# Altium schematic sheet fanled2014.SchDoc(fanled2014)
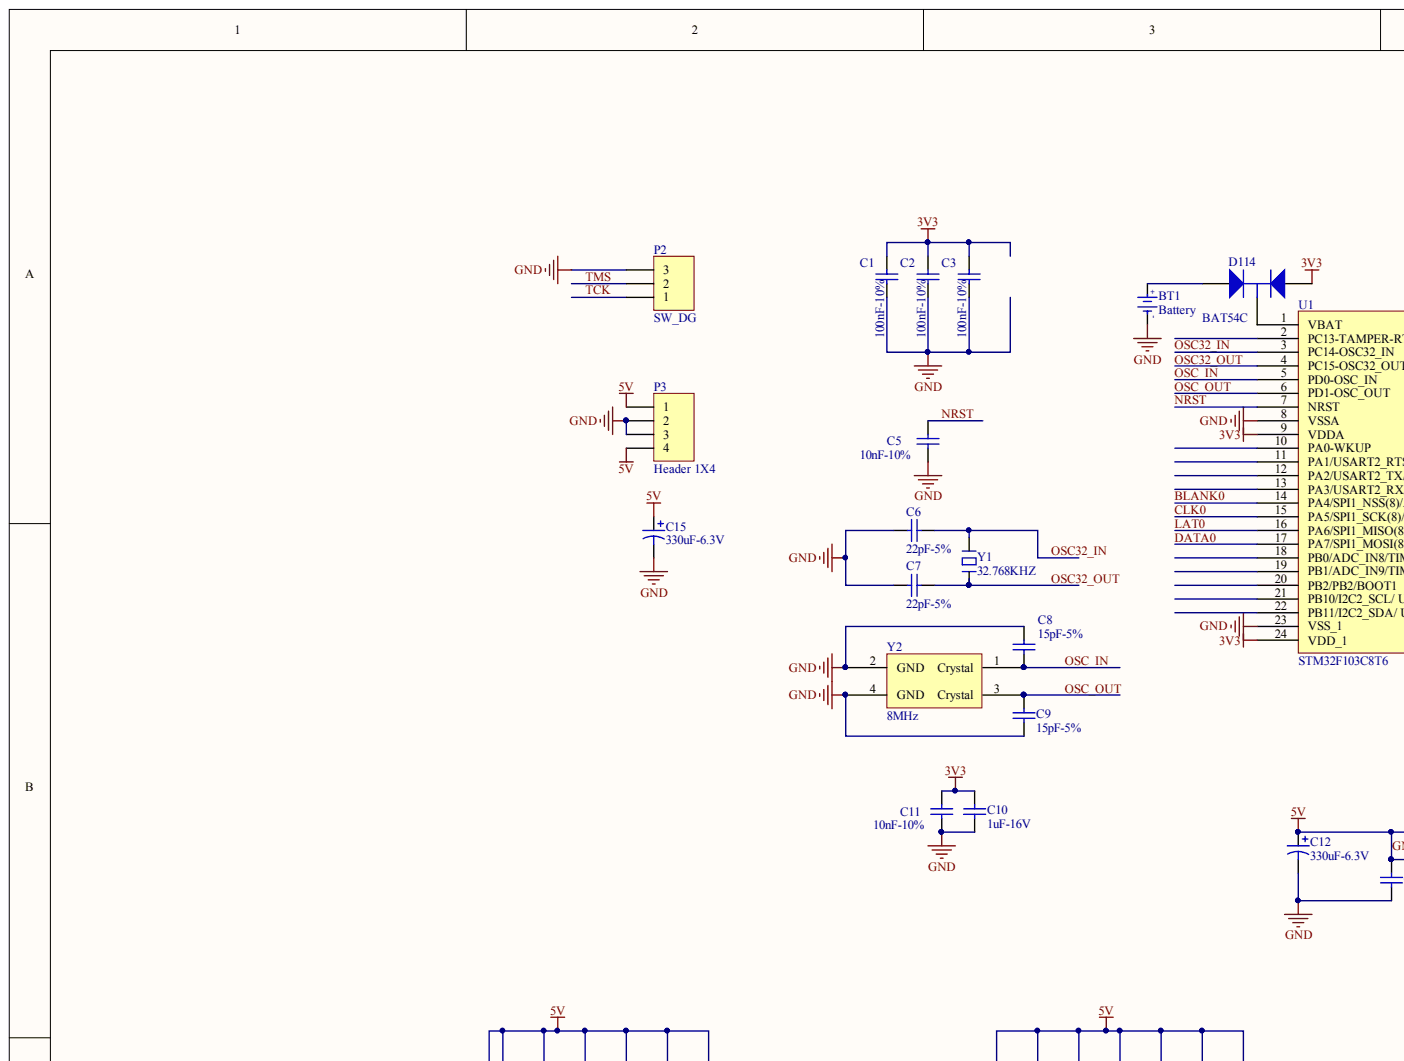
[diagram p0: fanled2014.SchDoc, top left]
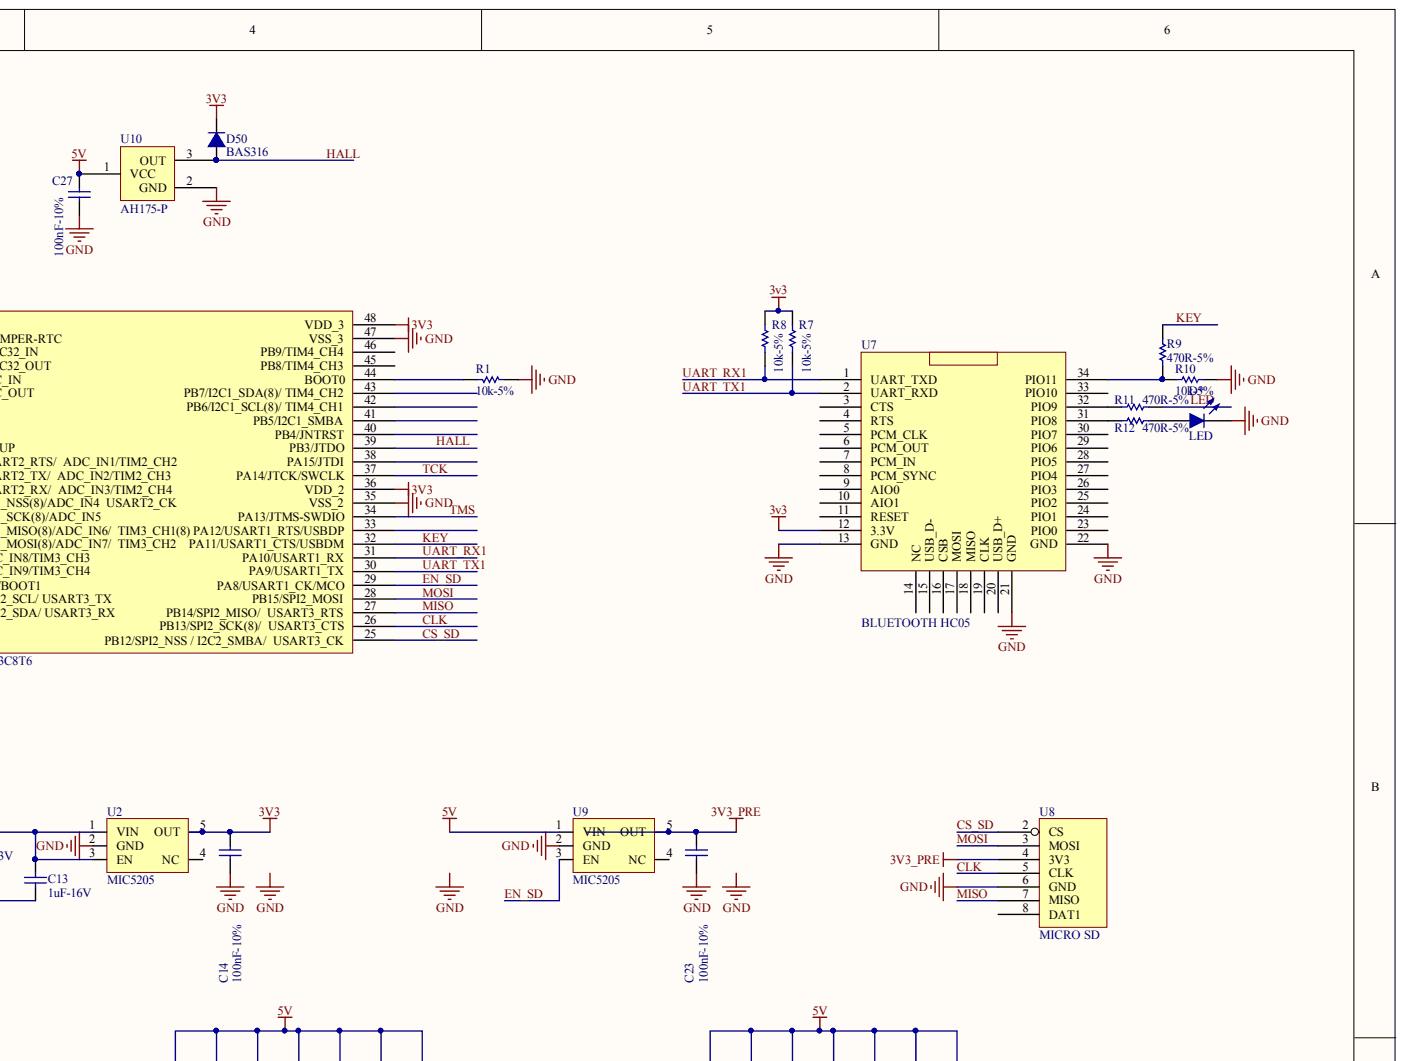
[diagram p1: fanled2014.SchDoc, top right]
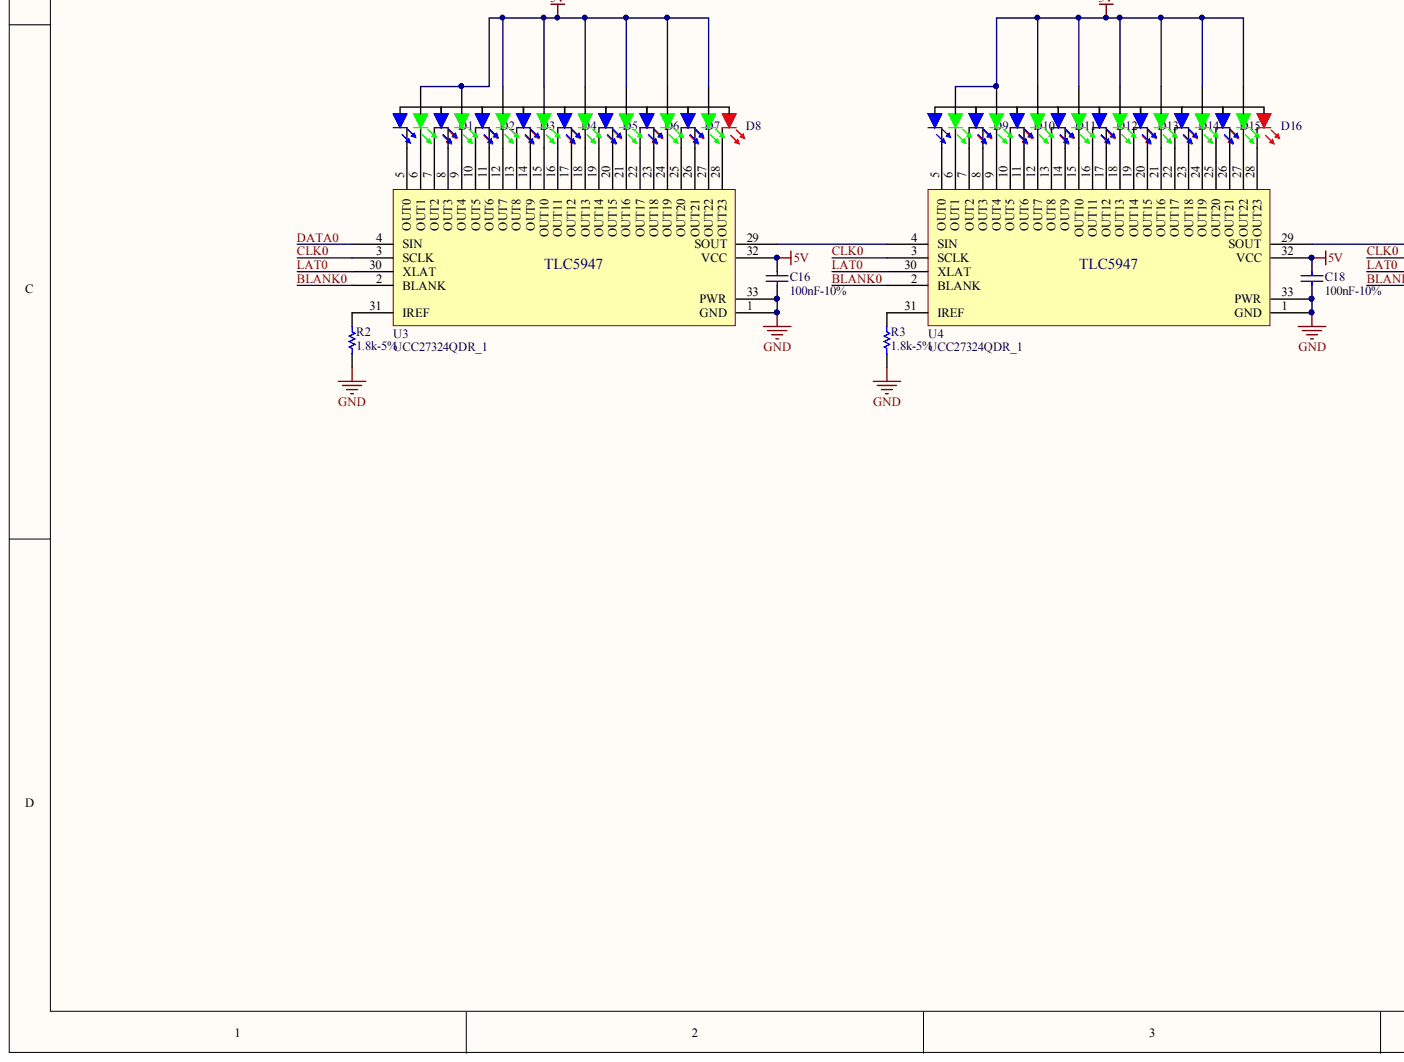
[diagram p2: fanled2014.SchDoc, bottom left]
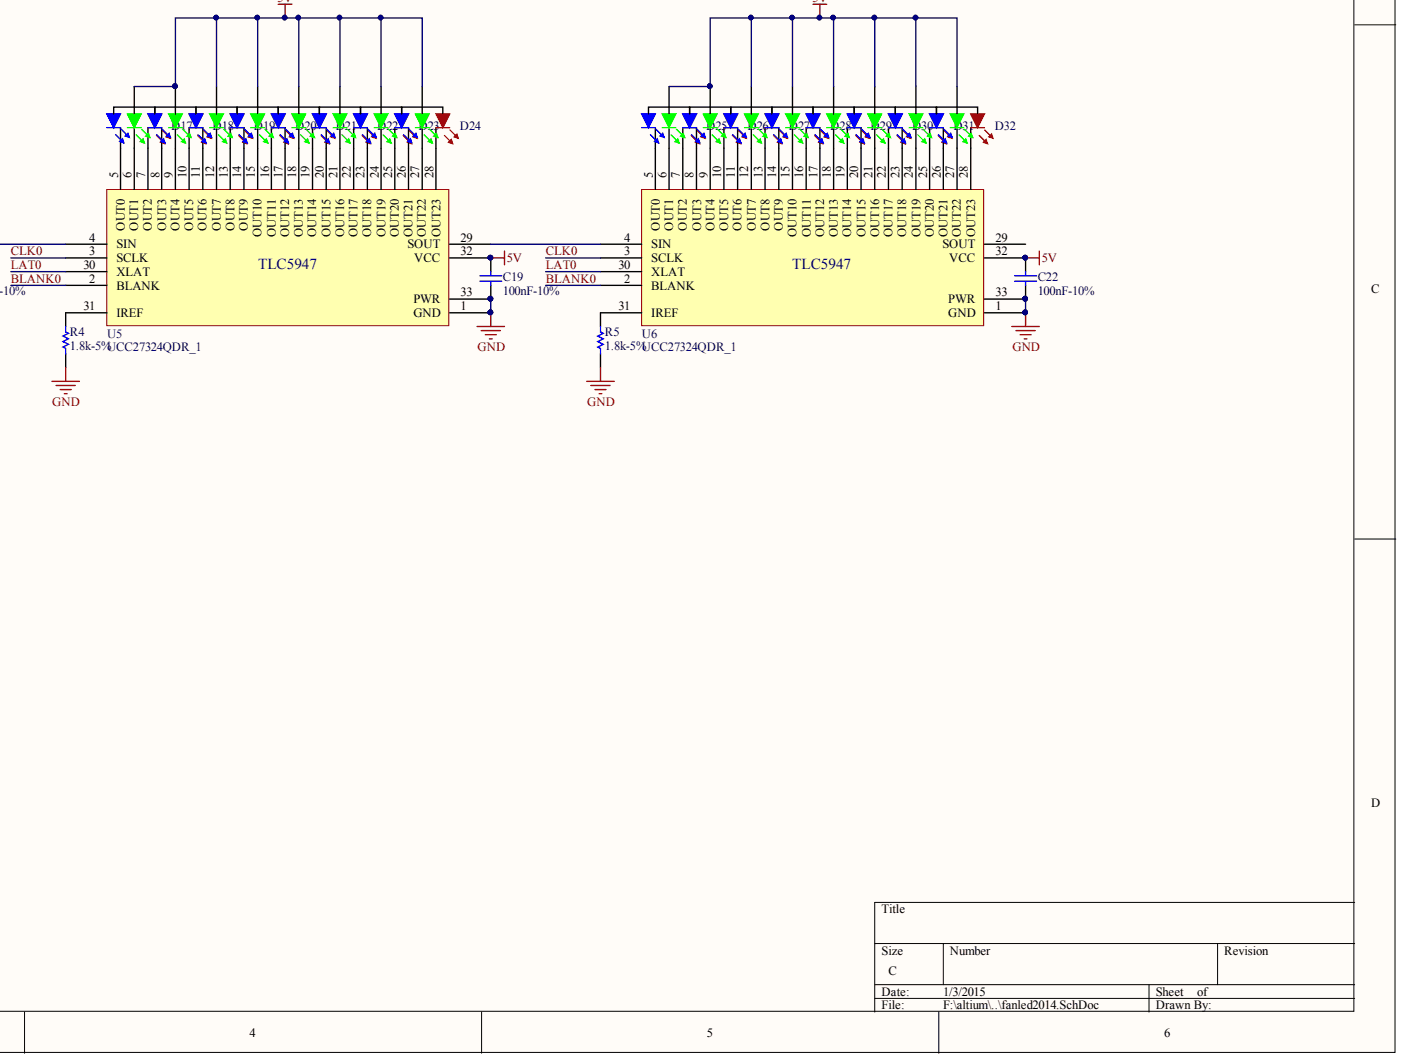
[diagram p3: fanled2014.SchDoc, bottom right]

## Parts
BT1  Battery  [BATTERY CR1220]
C1  100nF-10%  [C0603-T1]
C2  100nF-10%  [C0603-T1]
C3  100nF-10%  [C0603-T1]
C5  10nF-10%  [C0603-T1]
C6  22pF-5%  [C0603-T1]
C7  22pF-5%  [C0603-T1]
C8  15pF-5%  [C0603-T1]
C9  15pF-5%  [C0603-T1]
C10  1uF-16V  [C0603-T1]
C11  10nF-10%  [C0603-T1]
C12  330uF-6.3V  [C2917]
C13  1uF-16V  [C0603-T1]
C14  100nF-10%  [C0603-T1]
C15  330uF-6.3V  [C2917]
C16  100nF-10%  [C0603-T1]
C18  100nF-10%  [C0603-T1]
C19  100nF-10%  [C0603-T1]
C22  100nF-10%  [C0603-T1]
C23  100nF-10%  [C0603-T1]
C27  100nF-10%  [C0603-T1]
D1  LED1210_Tri color  [LED1210_Tri color]  (value not drawn)
D2  LED1210_Tri color  [LED1210_Tri color]  (value not drawn)
D3  LED1210_Tri color  [LED1210_Tri color]  (value not drawn)
D4  LED1210_Tri color  [LED1210_Tri color]  (value not drawn)
D5  LED1210_Tri color  [LED1210_Tri color]  (value not drawn)
D6  LED1210_Tri color  [LED1210_Tri color]  (value not drawn)
D7  LED1210_Tri color  [LED1210_Tri color]  (value not drawn)
D8  LED1210_Tri color  [LED1210_Tri color]  (value not drawn)
D9  LED1210_Tri color  [LED1210_Tri color]  (value not drawn)
D10  LED1210_Tri color  [LED1210_Tri color]  (value not drawn)
D11  LED1210_Tri color  [LED1210_Tri color]  (value not drawn)
D12  LED1210_Tri color  [LED1210_Tri color]  (value not drawn)
D13  LED1210_Tri color  [LED1210_Tri color]  (value not drawn)
D14  LED1210_Tri color  [LED1210_Tri color]  (value not drawn)
D15  LED1210_Tri color  [LED1210_Tri color]  (value not drawn)
D16  LED1210_Tri color  [LED1210_Tri color]  (value not drawn)
D17  LED1210_Tri color  [LED1210_Tri color]  (value not drawn)
D18  LED1210_Tri color  [LED1210_Tri color]  (value not drawn)
D19  LED1210_Tri color  [LED1210_Tri color]  (value not drawn)
D20  LED1210_Tri color  [LED1210_Tri color]  (value not drawn)
D21  LED1210_Tri color  [LED1210_Tri color]  (value not drawn)
D22  LED1210_Tri color  [LED1210_Tri color]  (value not drawn)
D23  LED1210_Tri color  [LED1210_Tri color]  (value not drawn)
D24  LED1210_Tri color  [LED1210_Tri color]  (value not drawn)
D25  LED1210_Tri color  [LED1210_Tri color]  (value not drawn)
D26  LED1210_Tri color  [LED1210_Tri color]  (value not drawn)
D27  LED1210_Tri color  [LED1210_Tri color]  (value not drawn)
D28  LED1210_Tri color  [LED1210_Tri color]  (value not drawn)
D29  LED1210_Tri color  [LED1210_Tri color]  (value not drawn)
D30  LED1210_Tri color  [LED1210_Tri color]  (value not drawn)
D31  LED1210_Tri color  [LED1210_Tri color]  (value not drawn)
D32  LED1210_Tri color  [LED1210_Tri color]  (value not drawn)
D50  BAS316  [SOD323]
D114  BAT54C  [SOT23]
D*  LED
P2  SW_DG  [HDR1X3]
P3  Header 1X4  [Connector fanled]
R1  10k-5%  [R0603]
R2  1.8k-5%  [R0603]
R3  1.8k-5%  [R0603]
R4  1.8k-5%  [R0603]
R5  1.8k-5%  [R0603]
R7  10k-5%  [R0603]
R8  10k-5%  [R0603]
R9  470R-5%  [R0603]
R10  10k-5%  [R0603]
R11  470R-5%  [R0603]
R12  470R-5%  [R0603]
U1  STM32F103C8T6  [TQFP-48-P0.5]
U2  MIC5205  [SOT23-5]
U3  UCC27324QDR_1  [TSSOP32 PowerPAD]
U4  UCC27324QDR_1  [TSSOP32 PowerPAD]
U5  UCC27324QDR_1  [TSSOP32 PowerPAD]
U6  UCC27324QDR_1  [TSSOP32 PowerPAD]
U7  BLUETOOTH HC05  [BLUETOOTH HC05]
U8  MICRO SD  [MicroSD Socket 2]
U9  MIC5205  [SOT23-5]
U10  AH175-P  [TO-92A]
Y1  32.768KHZ  [Crystal-3.2x1.5]
Y2  8MHz  [Crystal-5X3.2]

## Nets
3V3: C1.1 C2.1 C3.1 C10.1 C11.1 C14.2 D50.2 D114.1 R7.1 R8.1 U1.9(VDDA) U1.24(VDD_1) U1.36(VDD_2) U1.48(VDD_3) U2.5(OUT) U7.12(3.3V)
3V3_PRE: C23.2 U8.4(3V3) U9.5(OUT)
5V: C12.1 C13.2 C15.1 C16.2 C18.2 C19.2 C22.2 C27.1 D1.2 D2.2 D3.2 D4.2 D5.2 D6.2 D7.2 D8.2 D9.2 D10.2 D11.2 D12.2 D13.2 D14.2 D15.2 D16.2 D17.2 D18.2 D19.2 D20.2 D21.2 D22.2 D23.2 D24.2 D25.2 D26.2 D27.2 D28.2 D29.2 D30.2 D31.2 D32.2 P3.1 P3.4 U2.1(VIN) U2.3(EN) U3.32(VCC) U4.32(VCC) U5.32(VCC) U6.32(VCC) U9.1(VIN) U10.1
GND: BT1.2 C1.2 C2.2 C3.2 C5.2 C6.2 C7.2 C8.2 C9.1 C10.2 C11.2 C12.2 C13.1 C14.1 C15.2 C16.1 C18.1 C19.1 C22.1 C23.1 C27.2 D*.2 P2.3 P3.2 P3.3 R1.2 R2.1 R3.1 R4.1 R5.1 R10.1 U1.8(VSSA) U1.23(VSS_1) U1.35(VSS_2) U1.47(VSS_3) U2.2(GND) U3.1(GND) U3.33(PWR) U4.1(GND) U4.33(PWR) U5.1(GND) U5.33(PWR) U6.1(GND) U6.33(PWR) U7.13(GND) U7.21(GND) U7.22(GND) U8.6(GND) U9.2(GND) U10.2 Y2.2(GND) Y2.4(GND)
BLANK0: U1.14(PA4/SPI1_NSS(8)/ADC_IN4) U3.2(BLANK) U4.2(BLANK) U5.2(BLANK) U6.2(BLANK)
CLK: U1.26(USART3_CTS) U8.5(CLK)
CLK0: U1.15(PA5/SPI1_SCK(8)/ADC_IN5) U3.3(SCLK) U4.3(SCLK) U5.3(SCLK) U6.3(SCLK)
CS_SD: U1.25(USART3_CK) U8.2(CS)
DATA0: U1.17(PA7/SPI1_MOSI(8)/ADC_IN7/) U3.4(SIN)
EN_SD: U1.29(PA8/USART1_CK/MCO) U9.3(EN)
HALL: D50.1 U1.39(PB3/JTDO) U10.3
KEY: R9.2 U1.32(PA11/USART1_CTS/USBDM)
LAT0: U1.16(PA6/SPI1_MISO(8)/ADC_IN6/) U3.30(XLAT) U4.30(XLAT) U5.30(XLAT) U6.30(XLAT)
LED: R11.1
MISO: U1.27(USART3_RTS) U8.7(MISO)
MOSI: U1.28(PB15/SPI2_MOSI) U8.3(MOSI)
NRST: C5.1 U1.7(NRST)
OSC32_IN: C6.1 U1.3(PC14-OSC32_IN) Y1.2
OSC32_OUT: C7.1 U1.4(PC15-OSC32_OUT) Y1.1
OSC_IN: C8.1 U1.5(PD0-OSC_IN) Y2.1(Crystal)
OSC_OUT: C9.2 U1.6(PD1-OSC_OUT) Y2.3(Crystal)
TCK: P2.1 U1.37(PA14/JTCK/SWCLK)
TMS: P2.2 U1.34(PA13/JTMS-SWDIO)
UART_RX1: R8.2 U1.31(PA10/USART1_RX) U7.1(UART_TXD)
UART_TX1: R7.2 U1.30(PA9/USART1_TX) U7.2(UART_RXD)
NetBT1_1: BT1.1 D114.2
NetD1_1: D1.1 U3.7(OUT2)
NetD1_3: D1.3 U3.5(OUT0)
NetD1_4: D1.4 U3.6(OUT1)
NetD2_1: D2.1 U3.10(OUT5)
NetD2_3: D2.3 U3.8(OUT3)
NetD2_4: D2.4(D1) U3.9(OUT4)
NetD3_1: D3.1 U3.13(OUT8)
NetD3_3: D3.3 U3.11(OUT6)
NetD3_4: D3.4(D2) U3.12(OUT7)
NetD4_1: D4.1 U3.16(OUT11)
NetD4_3: D4.3 U3.14(OUT9)
NetD4_4: D4.4(D3) U3.15(OUT10)
NetD5_1: D5.1 U3.19(OUT14)
NetD5_3: D5.3 U3.17(OUT12)
NetD5_4: D5.4(D4) U3.18(OUT13)
NetD6_1: D6.1 U3.22(OUT17)
NetD6_3: D6.3 U3.20(OUT15)
NetD6_4: D6.4(D5) U3.21(OUT16)
NetD7_1: D7.1 U3.25(OUT20)
NetD7_3: D7.3 U3.23(OUT18)
NetD7_4: D7.4(D6) U3.24(OUT19)
NetD8_1: D8.1 U3.28(OUT23)
NetD8_3: D8.3 U3.26(OUT21)
NetD8_4: D8.4(D7) U3.27(OUT22)
NetD9_1: D9.1 U4.7(OUT2)
NetD9_3: D9.3 U4.5(OUT0)
NetD9_4: D9.4 U4.6(OUT1)
NetD10_1: D10.1 U4.10(OUT5)
NetD10_3: D10.3 U4.8(OUT3)
NetD10_4: D10.4(D9) U4.9(OUT4)
NetD11_1: D11.1 U4.13(OUT8)
NetD11_3: D11.3 U4.11(OUT6)
NetD11_4: D11.4 U4.12(OUT7)
NetD12_1: D12.1 U4.16(OUT11)
NetD12_3: D12.3 U4.14(OUT9)
NetD12_4: D12.4 U4.15(OUT10)
NetD13_1: D13.1 U4.19(OUT14)
NetD13_3: D13.3 U4.17(OUT12)
NetD13_4: D13.4 U4.18(OUT13)
NetD14_1: D14.1 U4.22(OUT17)
NetD14_3: D14.3 U4.20(OUT15)
NetD14_4: D14.4 U4.21(OUT16)
NetD15_1: D15.1 U4.25(OUT20)
NetD15_3: D15.3 U4.23(OUT18)
NetD15_4: D15.4 U4.24(OUT19)
NetD16_1: D16.1 U4.28(OUT23)
NetD16_3: D16.3 U4.26(OUT21)
NetD16_4: D16.4 U4.27(OUT22)
NetD17_1: D17.1 U5.7(OUT2)
NetD17_3: D17.3 U5.5(OUT0)
NetD17_4: D17.4 U5.6(OUT1)
NetD18_1: D18.1 U5.10(OUT5)
NetD18_3: D18.3 U5.8(OUT3)
NetD18_4: D18.4 U5.9(OUT4)
NetD19_1: D19.1 U5.13(OUT8)
NetD19_3: D19.3 U5.11(OUT6)
NetD19_4: D19.4 U5.12(OUT7)
NetD20_1: D20.1 U5.16(OUT11)
NetD20_3: D20.3 U5.14(OUT9)
NetD20_4: D20.4 U5.15(OUT10)
NetD21_1: D21.1 U5.19(OUT14)
NetD21_3: D21.3 U5.17(OUT12)
NetD21_4: D21.4 U5.18(OUT13)
NetD22_1: D22.1 U5.22(OUT17)
NetD22_3: D22.3 U5.20(OUT15)
NetD22_4: D22.4 U5.21(OUT16)
NetD23_1: D23.1 U5.25(OUT20)
NetD23_3: D23.3 U5.23(OUT18)
NetD23_4: D23.4 U5.24(OUT19)
NetD24_1: D24.1 U5.28(OUT23)
NetD24_3: D24.3 U5.26(OUT21)
NetD24_4: D24.4 U5.27(OUT22)
NetD25_1: D25.1 U6.7(OUT2)
NetD25_3: D25.3 U6.5(OUT0)
NetD25_4: D25.4 U6.6(OUT1)
NetD26_1: D26.1 U6.10(OUT5)
NetD26_3: D26.3 U6.8(OUT3)
NetD26_4: D26.4 U6.9(OUT4)
NetD27_1: D27.1 U6.13(OUT8)
NetD27_3: D27.3 U6.11(OUT6)
NetD27_4: D27.4 U6.12(OUT7)
NetD28_1: D28.1 U6.16(OUT11)
NetD28_3: D28.3 U6.14(OUT9)
NetD28_4: D28.4 U6.15(OUT10)
NetD29_1: D29.1 U6.19(OUT14)
NetD29_3: D29.3 U6.17(OUT12)
NetD29_4: D29.4 U6.18(OUT13)
NetD30_1: D30.1 U6.22(OUT17)
NetD30_3: D30.3 U6.20(OUT15)
NetD30_4: D30.4 U6.21(OUT16)
NetD31_1: D31.1 U6.25(OUT20)
NetD31_3: D31.3 U6.23(OUT18)
NetD31_4: D31.4 U6.24(OUT19)
NetD32_1: D32.1 U6.28(OUT23)
NetD32_3: D32.3 U6.26(OUT21)
NetD32_4: D32.4 U6.27(OUT22)
NetD114_3: D114.3 U1.1(VBAT)
NetD*_1: D*.1 R12.1
NetR1_1: R1.1 U1.44(BOOT0)
NetR2_2: R2.2 U3.31(IREF)
NetR3_2: R3.2 U4.31(IREF)
NetR4_2: R4.2 U5.31(IREF)
NetR5_2: R5.2 U6.31(IREF)
NetR9_1: R9.1 R10.2 U7.34(PIO11)
NetR11_2: R11.2 U7.32(PIO9)
NetR12_2: R12.2 U7.31(PIO8)
NetU3_29: U3.29(SOUT) U4.4(SIN)
NetU4_29: U4.29(SOUT) U5.4(SIN)
NetU5_29: U5.29(SOUT) U6.4(SIN)

Not connected: U1 2(PC13-TAMPER-RTC) 10(PA0-WKUP) 11(PA1/USART2_RTS/) 12(PA2/USART2_TX/) 13(PA3/USART2_RX/) 18(PB0/ADC_IN8/TIM3_CH3) 19(PB1/ADC_IN9/TIM3_CH4) 20(PB2/PB2/BOOT1) 21(PB10/I2C2_SCL/_USART3_TX) 22(PB11/I2C2_SDA/_USART3_RX) 33(TIM3_CH1(8)_PA12/USART1_RTS/USBDP) 38(PA15/JTDI) 40(PB4/JNTRST) 41(PB5/I2C1_SMBA) 42(PB6/I2C1_SCL(8)/_TIM4_CH1) 43(PB7/I2C1_SDA(8)/_TIM4_CH2) 45(PB8/TIM4_CH3) 46(PB9/TIM4_CH4); U2 4(NC); U6 29(SOUT); U7 3(CTS) 4(RTS) 5(PCM_CLK) 6(PCM_OUT) 7(PCM_IN) 8(PCM_SYNC) 9(AIO0) 10(AIO1) 11(RESET) 14(NC) 15(USB_D-) 16(CSB) 17(MOSI) 18(MISO) 19(CLK) 20(USB_D+) 23(PIO0) 24(PIO1) 25(PIO2) 26(PIO3) 27(PIO4) 28(PIO5) 29(PIO6) 30(PIO7) 33(PIO10); U8 8(DAT1); U9 4(NC)

## Library data of the parts
BT1 = Battery: Battery 3VDC; Value: PIN 3V; Component Kind: Standard; Library Name: Connector.SchLib
C1-C3 C14 C16 C18 C19 C22 C23 C27 = 100nF-50V-C0805: Non-Polarized Capacitor; Manufacturer: Yageo; Manufacturer Part Number: CC0805KRX7R9BB104; Component Kind: Standard; Digi-Key Part Number: 311-1140-1-ND; Library Name: Capacitor.SchLib; Unit Price: 0.1; Value: 100nF-10%
C5 C11 = 10nF-50V-C0805: Non-Polarized Capacitor; Manufacturer: Yageo; Manufacturer Part Number: CC0805KRX7R9BB103; Component Kind: Standard; Digi-Key Part Number: 311-1136-1-ND; Library Name: Capacitor.SchLib; Unit Price: 0.1; Value: 10nF-10%
C6 C7 = 22pF-50V-C0805: Non-Polarized Capacitor; Manufacturer: Yageo; Manufacturer Part Number: CC0805JRNPO9BN220; Component Kind: Standard; Digi-Key Part Number: 311-1103-1-ND; Library Name: Capacitor.SchLib; Unit Price: 0.1; Value: 22pF-5%
C8 C9 = 15pF-50V-C0805: Non-Polarized Capacitor; Manufacturer: Yageo; Manufacturer Part Number: CC0805JRNPO9BN150; Component Kind: Standard; Digi-Key Part Number: 311-1101-1-ND; Library Name: Capacitor.SchLib; Unit Price: 0.1; Value: 15pF-5%
C10 C13 = 1uF-16V-C0805: Non-Polarized Capacitor; Manufacturer: Yageo; Manufacturer Part Number: CC0805KKX7R7BB105; Component Kind: Standard; Digi-Key Part Number: 311-1365-1-ND; Library Name: Capacitor.SchLib; Unit Price: 0.1; Value: 1uF-16V
C12 C15 = 330uF-6.3V-Tantalum: CAP TANT 330UF 6.3V 10% 2917; Manufacturer: AVX Corporation; Manufacturer Part Number: TAJY337K006RNJ; Component Kind: Standard; Digi-Key Part Number: 478-3277-1-ND; Library Name: Capacitor.SchLib; Unit Price: 2.21; Value: 330uF-6.3V
D50 = BAS316: DIODE SWITCH 100V 0.25A SOD323; Manufacturer: NXP Semiconductors; Manufacturer Part Number: BAS316,115; Component Kind: Standard; Digi-Key Part Number: 568-5003-1-ND; Library Name: Diode.SchLib; Unit Price: 0.04971
D114 = DZ23C22: 300mW Dual Surface Mount Zener Diode; Component Kind: Standard; ComponentLink1Description: Manufacturer Link; ComponentLink1URL: http://www.vishay.com/; DatasheetDocument: REV. G; LatestRevisionDate: 17-Jul-2002; LatestRevisionNote: Re-released for DXP Platform.; Library Name: thu vien General IC.SchLib; PackageReference: SOT-23; Published: 8-Jun-2000; Signal Integrity: DZ23C22; Simulation: DZ23C22; Publisher: Altium Limited
P2 = Header 3: Header, 3-Pin; Component Kind: Standard; LatestRevisionDate: 17-Jul-2002; LatestRevisionNote: Re-released for DXP Platform.; Library Name: Miscellaneous Connectors.IntLib; Signal Integrity: Connector; Publisher: Altium Limited
P3 = Header 1X4: Header, 4-Pin; Component Kind: Standard; Library Name: Connector.SchLib
R1 R7 R8 R10 = 10k-5%-R0805: RES 10k OHM 1/8W 5% 0805 SMD; Manufacturer: Yageo; Manufacturer Part Number: RC0805JR-0710KL; Component Kind: Standard; Digi-Key Part Number: 311-10KARTR-ND; Unit Price: 0.00209
  R1: Library Name: Resistor.SchLib
  R7 R8 R10: Library Name: All_Resistor.SchLib
R2-R5 = 1.8k-5%-R0805: RES 1.8k OHM 1/8W 5% 0805 SMD; Manufacturer: Yageo; Manufacturer Part Number: RC0805JR-071K8L; Component Kind: Standard; Digi-Key Part Number: 311-1.8KARTR-ND; Library Name: All_Resistor.SchLib; Unit Price: 0.00209
R9 R11 R12 = 470R-5%-R0805: RES 470 OHM 1/8W 5% 0805 SMD; Manufacturer: Yageo; Manufacturer Part Number: RC0805JR-07470RL; Component Kind: Standard; Digi-Key Part Number: 311-470ARTR-ND; Library Name: All_Resistor.SchLib; Unit Price: 0.00209
U1 = STM32F103C8T6: 48pins, 64 KBytes Flash, 20 KBytes SRAM, 1x I2C, 1x SPI (18 Mbit/s); Component Kind: Standard; Datasheet: http://www.st.com/internet/com/TECHNICAL_RESOURCES/TECHNICAL_LITERATURE/DATASHEET/CD00210831.pdf; Library Name: STMicroelectronics.SchLib
U2 U9 = MIC5205 SOT-25: IC REG LDO 3.3V 0.15A SOT23-5; Manufacturer: Micrel Inc; Manufacturer Part Number: MIC5205-3.3YM5 TR; Component Kind: Standard; Digi-Key Part Number: 576-1259-1-ND; Library Name: power_ic.SchLib; Unit Price: 0.26513
U3-U6 = UCC27324QDR_1: IC LED DRIVER LINEAR 32HTSSOP; Manufacturer: Texas Instruments; Manufacturer Part Number: TLC5947DAP; Component Kind: Standard; Digi-Key Part Number: 296-23576-5-ND; Library Name: driver ic.SchLib; Unit Price: 2.63250
U10 = AH175-P: HALL SENSOR LATCH 25MA SIP-3L; Component Kind: Standard; Library Name: Schlib1.SchLib
Y1 = 32.768KHZ: CRYSTAL 32.768KHZ 12.5PF SMD; Manufacturer: ECS Inc; Manufacturer Part Number: ECS-.327-12.5-39-TR; Component Kind: Standard; Digi-Key Part Number: XC1658CT-ND; Library Name: crystal.SchLib; Unit Price: 0.48000; value: 32.768KHZ
Y2 = Crystal 8MHz: CRYSTAL 8MHZ 18PF SMD; Manufacturer: TXC CORPORATION; Manufacturer Part Number: 7B-8.000MAAJ-T; Component Kind: Standard; Digi-Key Part Number: 887-1452-1-ND; Library Name: crystal.SchLib
D1-D32 = LED1210_Tri color: Component Kind: Standard; Library Name: Diode.SchLib
D* = LED: Component Kind: Standard; Name: LED; Library Name: tranhieu_hcmut.SchLib
U7 = BLUETOOTH HC05: Component Kind: Standard; Library Name: bluetooth HC05.SchLib
U8 = MICRO SD: Component Kind: Standard; Library Name: msp430g2553.SchLib
